# Altium schematic sheet Buck_CurrentRegulator.SchDoc(Buck_CurrentRegulator)
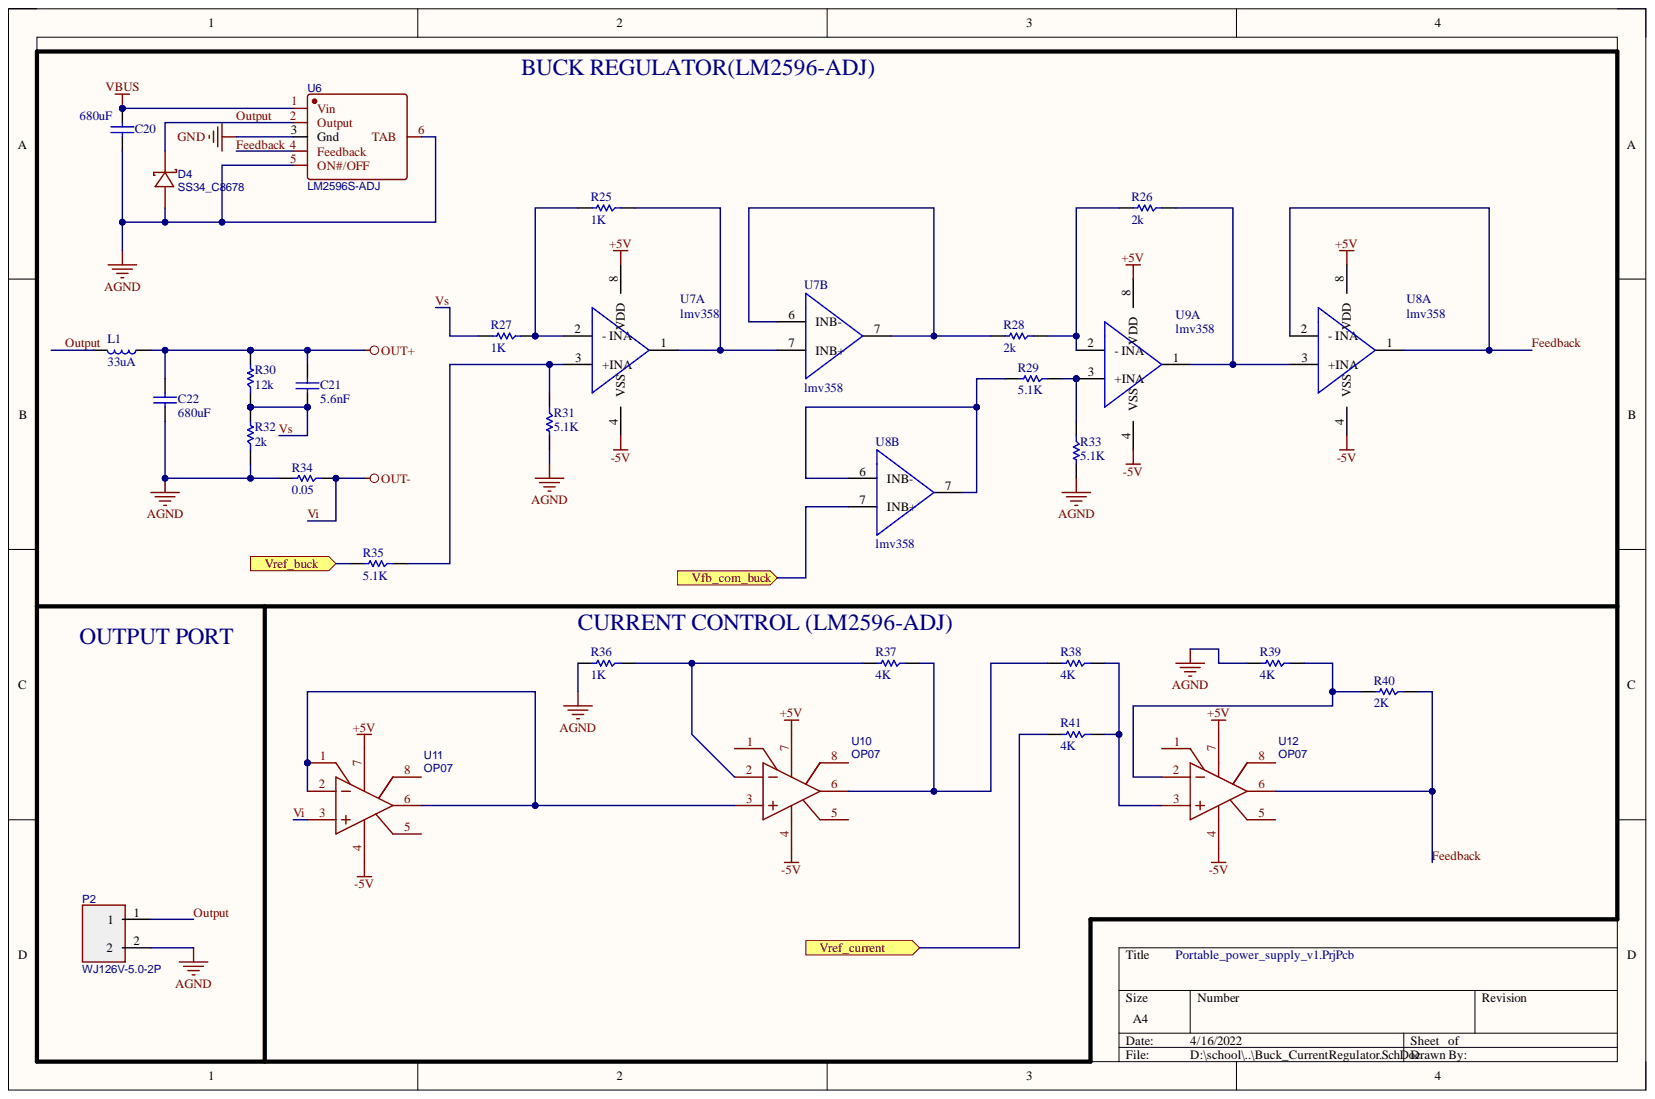
[diagram p0: Buck_CurrentRegulator.SchDoc]

## Parts
C20  680uF  [0805C]
C21  5.6nF  [0805C]
C22  680uF  [0805C]
D4  SS34_C8678  [DO-214AC_L4.3-W2.7-LS5.3-RD]
L1  33uA  [0805]
P2  WJ126V-5.0-2P  [CONN-TH_WJ126V-5.0-2P]
R25  1K  [0805]
R26  2k  [0805]
R27  1K  [0805]
R28  2k  [0805]
R29  5.1K  [0805]
R30  12k  [0805]
R31  5.1K  [0805]
R32  2k  [0805]
R33  5.1K  [0805]
R34  0.05  [0805]
R35  5.1K  [0805]
R36  1K  [0805]
R37  4K  [0805]
R38  4K  [0805]
R39  4K  [0805]
R40  2K  [0805]
R41  4K  [0805]
U6  LM2596S-ADJ  [TO-263-5_L10.2-W8.9-P1.70-BR]
U7  lmv358  [SOP-8_L4.9-W3.9-P1.27-LS6.0-BL]  (parts A,B)
U8  lmv358  [SOP-8_L4.9-W3.9-P1.27-LS6.0-BL]  (parts A,B)
U9  lmv358  [SOP-8_L4.9-W3.9-P1.27-LS6.0-BL]  (part A)
U10  OP07  [SOP-8_L4.9-W3.9-P1.27-LS6.0-BL]
U11  OP07  [SOP-8_L4.9-W3.9-P1.27-LS6.0-BL]
U12  OP07  [SOP-8_L4.9-W3.9-P1.27-LS6.0-BL]

## Ports
Vfb_com_buck, Vref_buck, Vref_current

## Nets
+5V: U7.8(VDD) U8.8(VDD) U9.8(VDD) U10.7 U11.7 U12.7
-5V: U7.4(VSS) U8.4(VSS) U9.4(VSS) U10.4 U11.4 U12.4
AGND: C20.2 C22.1 D4.2 P2.2 R31.2 R32.1 R33.2 R34.2 R36.2 R39.2 U6.5(ON#/OFF) U6.6(TAB)
GND: U6.3(Gnd)
OUT+: C21.1 C22.2 L1.1 R30.2
VBUS: C20.1 U6.1(Vin)
Feedback: R40.1 U6.4(Feedback) U8.1 U8.2(-_INA) U12.6
Output: D4.1 L1.2 P2.1 U6.2(Output)
Vfb_com_buck: U8.7 ; port Vfb_com_buck
Vi: R34.1 U11.3
Vref_buck: R35.2 ; port Vref_buck
Vref_current: R41.2 ; port Vref_current
Vs: C21.2 R27.2 R30.1 R32.2
NetR25_1: R25.1 U7.1 U7.7
NetR25_2: R25.2 R27.1 U7.2(-_INA)
NetR26_1: R26.1 U8.3(+INA) U9.1
NetR26_2: R26.2 R28.1 U9.2(-_INA)
NetR28_2: R28.2 U7.6(INB-) U7.7
NetR29_1: R29.1 R33.1 U9.3(+INA)
NetR29_2: R29.2 U8.6(INB-) U8.7
NetR31_1: R31.1 R35.1 U7.3(+INA)
NetR36_1: R36.1 R37.2 U10.2
NetR37_1: R37.1 R38.2 U10.6
NetR38_1: R38.1 R41.1 U12.3
NetR39_1: R39.1 R40.2 U12.2
NetU10_3: U10.3 U11.1 U11.2 U11.6

Not connected: U10 1 5 8; U11 5 8; U12 1 5 8

## Library data of the parts
C20 = C_680uf: Capacitor; Reviewed by: RM - 2018/08/05; Component Kind: Standard; Revision: July-2002: Re-released for DXP Platform.; Simulation: CAP; Value: 680uF; Library Name: Portable power supply.IntLib
C21 = C_7: Capacitor; Reviewed by: RM - 2018/08/05; Component Kind: Standard; Revision: July-2002: Re-released for DXP Platform.; Simulation: CAP; Value: 5.6nF; Library Name: Portable power supply.IntLib
C22 = C_11: Capacitor; Reviewed by: RM - 2018/08/05; Component Kind: Standard; Revision: July-2002: Re-released for DXP Platform.; Simulation: CAP; Value: 680uF; Library Name: Portable power supply.IntLib
D4 = SS34_C8678: BOM_Manufacturer: MDD; BOM_Manufacturer Part: SS34; BOM_JLCPCB Part Class: Basic Part; BOM_Supplier: LCSC; BOM_Supplier Part: C8678; Component Kind: Standard; spicePre: D; spiceSymbolName: SS34_C8678; Library Name: Portable power supply.IntLib
L1 = I: Inductor; Reviewed by: RM - 2018/08/05; Component Kind: Standard; Value: 33uA; Library Name: Portable power supply.IntLib
P2 = WJ126V-5.0-2P: BOM_Manufacturer: ReliaPro; BOM_JLCPCB Part Class: Extended Part; BOM_Manufacturer Part: WJ126V-5.0-2P; BOM_Supplier: LCSC; BOM_Supplier Part: C8404; Component Kind: Standard; spicePre: P; spiceSymbolName: WJ126V-5.0-2P; Library Name: Portable power supply.IntLib
R25 R27 R34 = R_6: Resistor; Reviewed by: RM - 2018/08/05; Component Kind: Standard; Simulation: RES; Library Name: Portable power supply.IntLib
  R25 R27: Value: 1K
  R34: Value: 0.05
R26 R28 R32 = R_3: Resistor; Reviewed by: RM - 2018/08/05; Component Kind: Standard; Simulation: RES; Value: 2k; Library Name: Portable power supply.IntLib
R29 R31 R33 R35 = R_1: Resistor; Reviewed by: RM - 2018/08/05; Component Kind: Standard; Simulation: RES; Value: 5.1K; Library Name: Portable power supply.IntLib
R30 = R_2: Resistor; Reviewed by: RM - 2018/08/05; Component Kind: Standard; Simulation: RES; Value: 12k; Library Name: Portable power supply.IntLib
R36-R41 = R_1k: Resistor; Reviewed by: RM - 2018/08/05; Component Kind: Standard; Simulation: RES; Library Name: power supply ztc.IntLib
  R36: Value: 1K
  R37-R39 R41: Value: 4K
  R40: Value: 2K
U6 = LM2596S-ADJ: BOM_Manufacturer: UMW(Youtai Semiconductor Co., Ltd.); Contributor: LCSC; BOM_JLCPCB Part Class: Extended Part; BOM_Manufacturer Part: LM2596S-ADJ; BOM_Supplier: LCSC; BOM_Supplier Part: C347423; Component Kind: Standard; spicePre: U; spiceSymbolName: LM2596S-ADJ; Library Name: Portable power supply.IntLib
U7-U9 = LMV358-SR: OpAmp High Frequency Dual; Reviewed by: RM - 2018/08/05; Component Kind: Standard; Library Name: Portable power supply.IntLib
U10-U12 = OP07: BOM_Manufacturer: Hangzhou Ruimeng Tech; Contributor: LCSC; BOM_JLCPCB Part Class: Extended Part; BOM_Manufacturer Part: OP07; BOM_Supplier: LCSC; BOM_Supplier Part: C1508997; Component Kind: Standard; Library Name: op07.SCHLIB; spicePre: U; spiceSymbolName: OP07
